# Altium schematic sheet Main.SchDoc
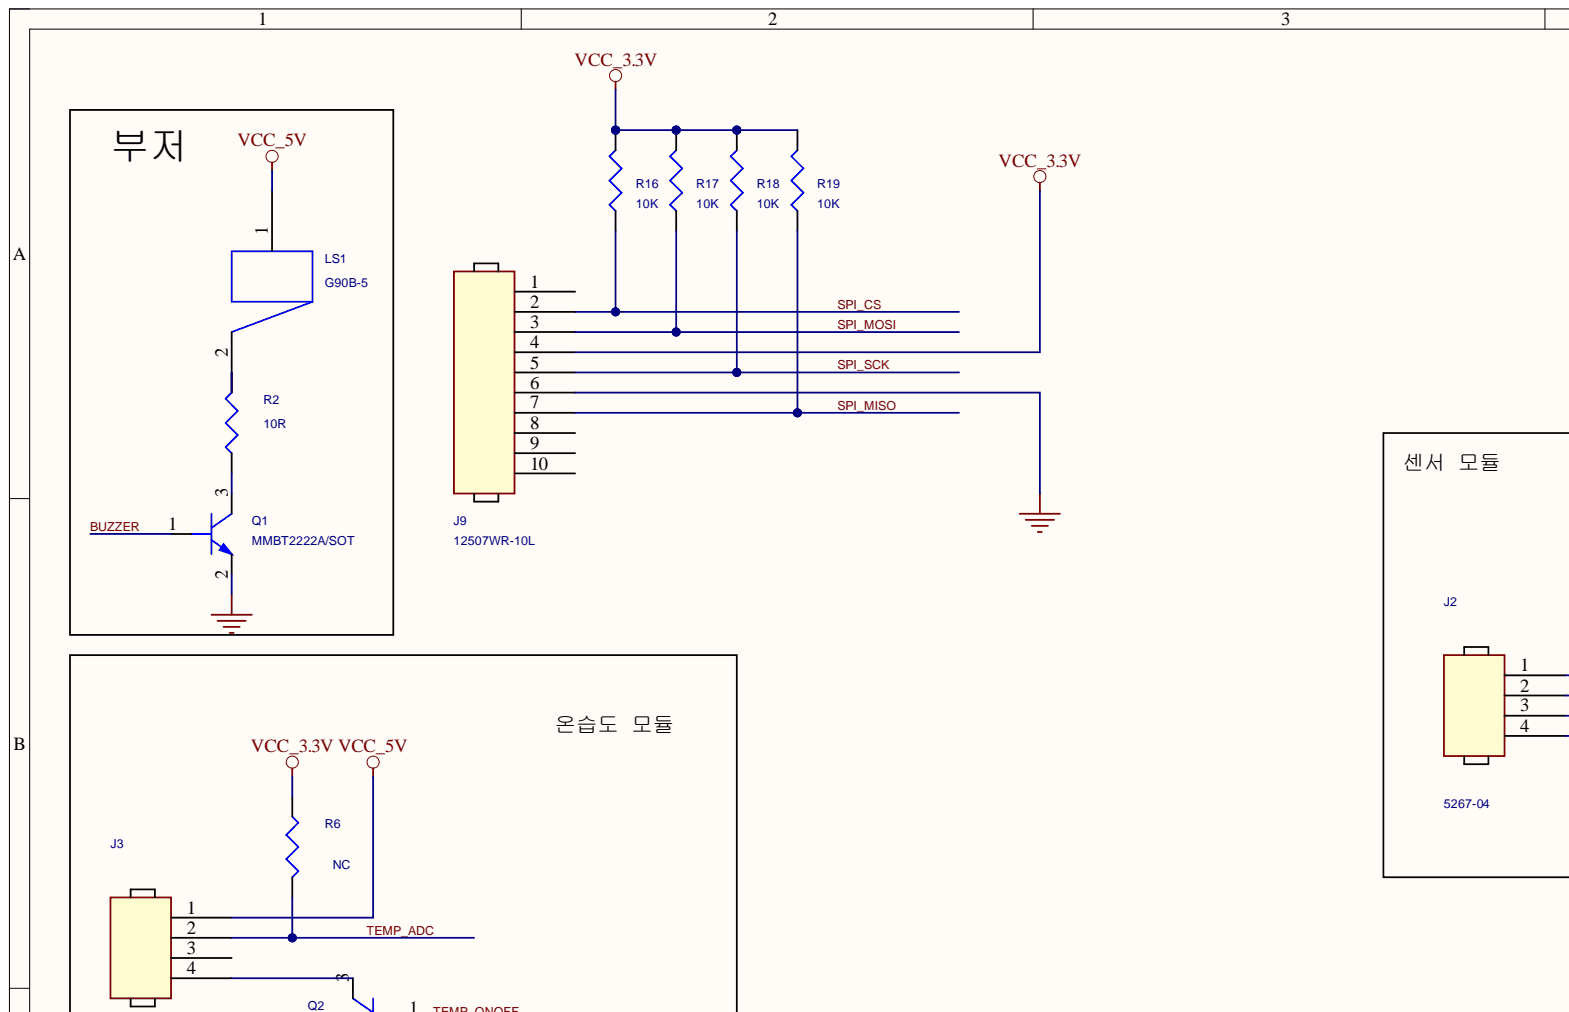
[diagram p0: Main.SchDoc, top left]
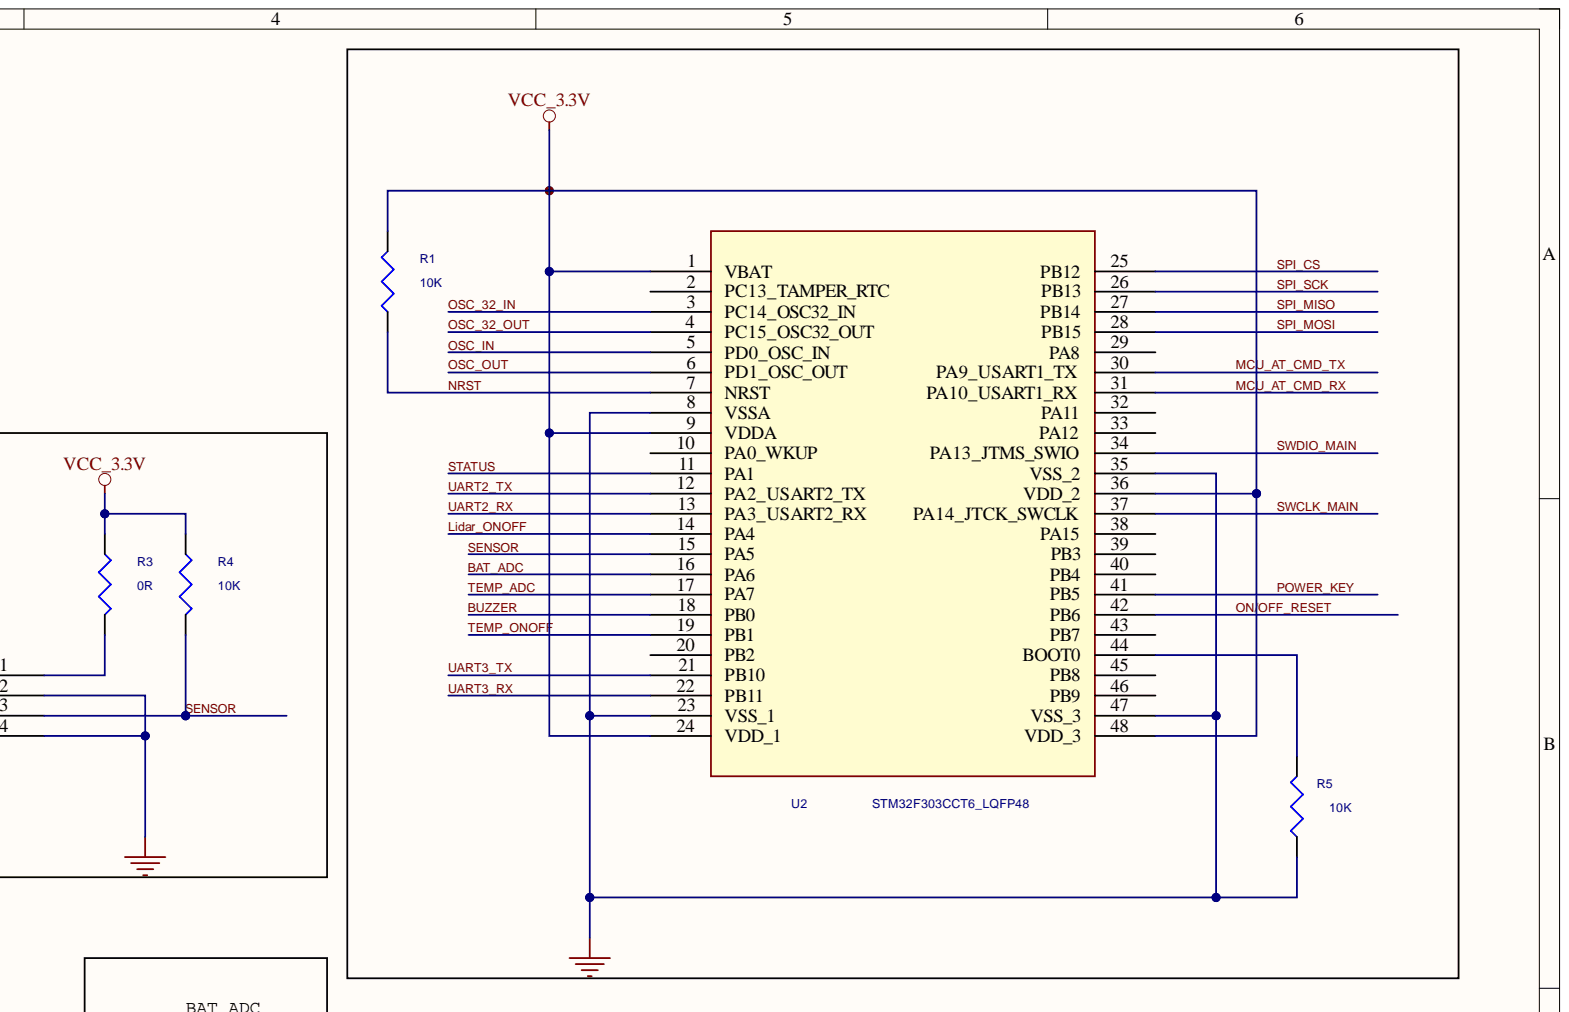
[diagram p1: Main.SchDoc, top right]
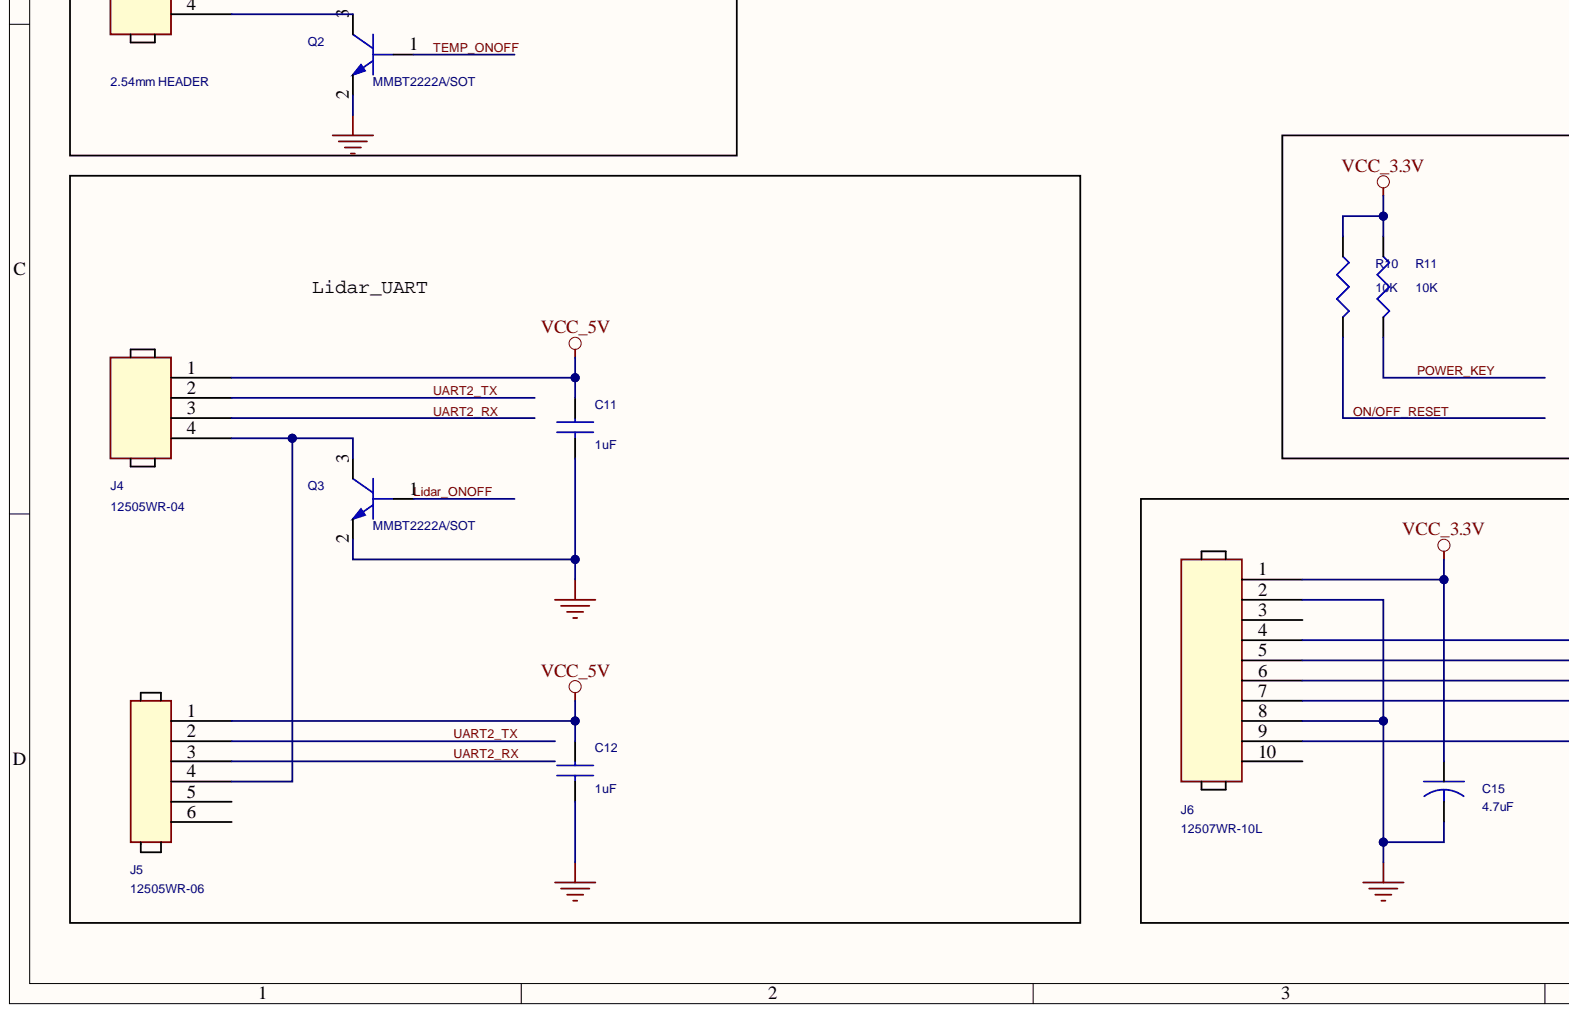
[diagram p2: Main.SchDoc, bottom left]
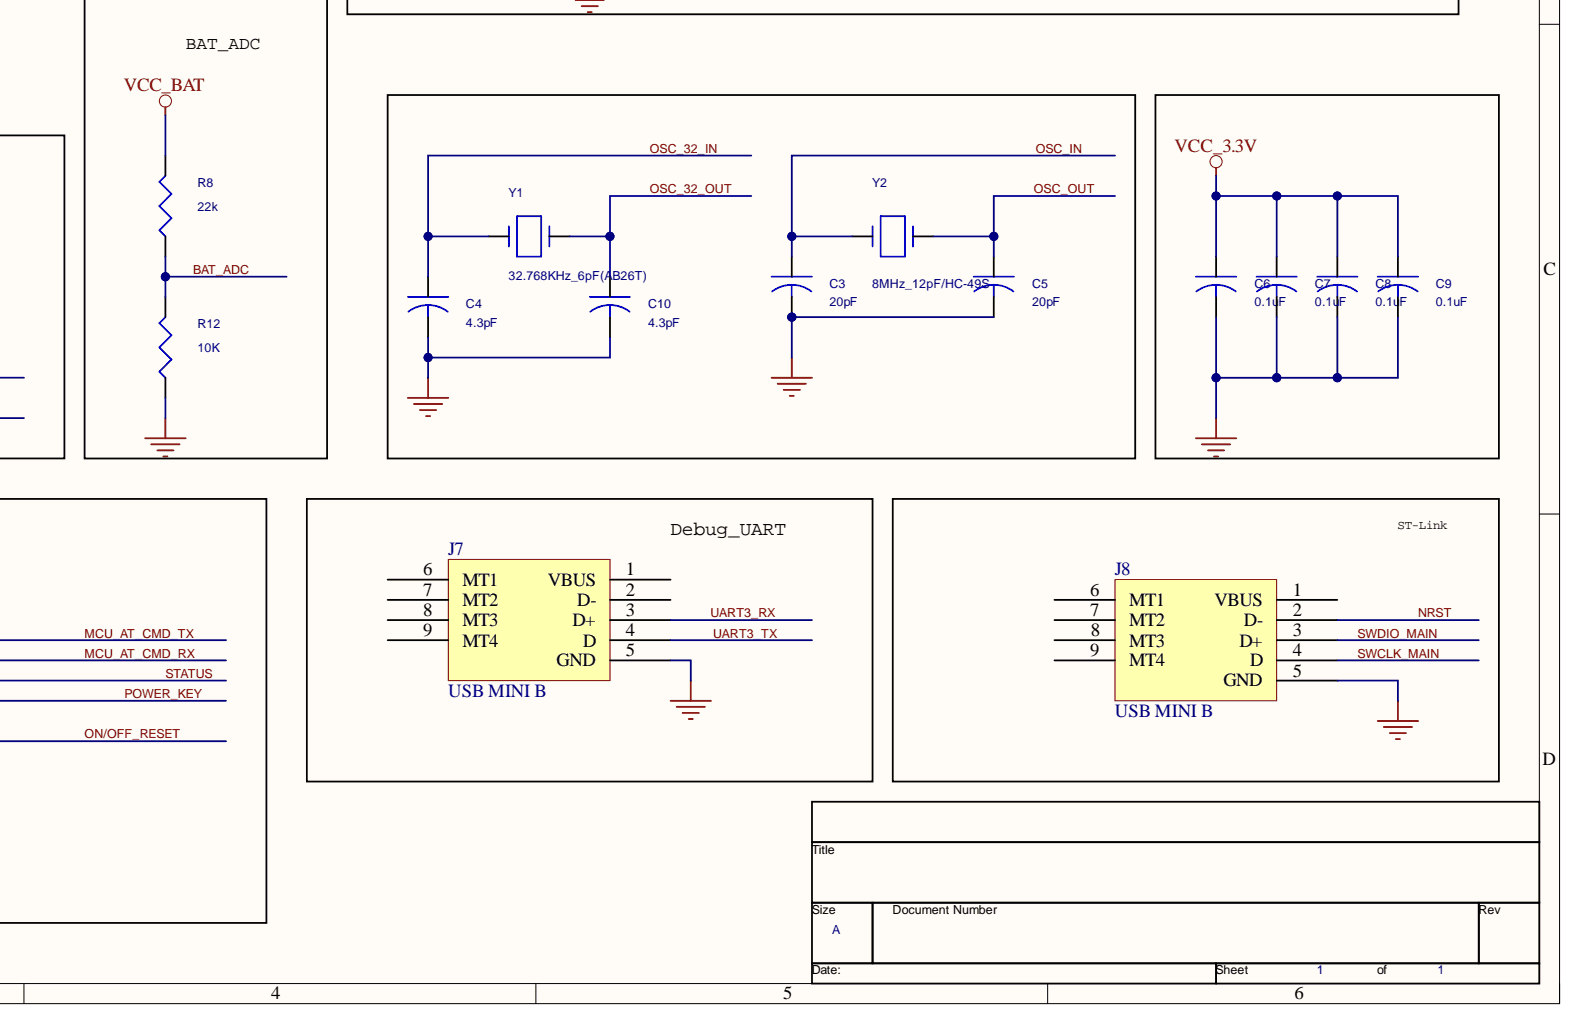
[diagram p3: Main.SchDoc, bottom right]

## Parts
C3  20pF  [DM_CC1608]
C4  4.3pF  [DM_CC1608]
C5  20pF  [DM_CC1608]
C6  0.1uF  [DM_CC1608]
C7  0.1uF  [DM_CC1608]
C8  0.1uF  [DM_CC1608]
C9  0.1uF  [DM_CC1608]
C10  4.3pF  [DM_CC1608]
C11  1uF  [C1608_CRABLED]
C12  1uF  [C1608_CRABLED]
C15  4.7uF  [DM_CC1608]
J2  5267-04  [5267-04]
J3  2.54mm HEADER  [HEADER4_2.54]
J4  12505WR-04  [12505WR-04]
J5  12505WR-06  [12505WR-06]
J6  12507WR-10L  [12507WR-10L]
J7  USB MINI B  [USB-MINI-A]
J8  USB MINI B  [USB-MINI-A]
J9  12507WR-10L  [Micro SD]
LS1  G90B-5  [G90B-5]
Q1  MMBT2222A/SOT  [SOT23]
Q2  MMBT2222A/SOT  [SOT23]
Q3  MMBT2222A/SOT  [SOT23]
R1  10K  [DM_CR1608]
R2  10R  [DM_CR1608]
R3  0R  [DM_CR1608]
R4  10K  [DM_CR1608]
R5  10K  [DM_CR1608]
R6  NC  [DM_CR1608]
R8  22k  [DM_CR1608]
R10  10K  [DM_CR1608]
R11  10K  [DM_CR1608]
R12  10K  [DM_CR1608]
R16  10K  [DM_CR1608]
R17  10K  [DM_CR1608]
R18  10K  [DM_CR1608]
R19  10K  [DM_CR1608]
U2  STM32F303CCT6_LQFP48  [LQFP48P_7X7MM]
Y1  32.768KHz_6pF(AB26T)  [32.768KHZ_6PF(AB26T)]
Y2  8MHz_12pF/HC-49S  [OSC HC-49SMD]

## Nets
GND: C3.2 C4.2 C5.2 C6.2 C7.2 C8.2 C9.2 C10.2 C11.2 C12.2 C15.2 J2.2 J2.4 J6.2 J6.8 J7.5(GND) J8.5(GND) J9.6 Q1.2 Q2.2 Q3.2 R5.1 R12.1 U2.8(VSSA) U2.23(VSS_1) U2.35(VSS_2) U2.47(VSS_3)
VCC_3.3V: C6.1 C7.1 C8.1 C9.1 C15.1 J6.1 J9.4 R1.2 R3.1 R4.1 R6.1 R10.1 R11.1 R16.2 R17.2 R18.2 R19.2 U2.1(VBAT) U2.9(VDDA) U2.24(VDD_1) U2.36(VDD_2) U2.48(VDD_3)
VCC_5V: C11.1 C12.1 J3.1 J4.1 J5.1 LS1.1
VCC_BAT: R8.2
BAT_ADC: R8.1 R12.2 U2.16(PA6)
BUZZER: Q1.1 U2.18(PB0)
Lidar_ONOFF: Q3.1 U2.14(PA4)
MCU_AT_CMD_RX: J6.5 U2.31(PA10_USART1_RX)
MCU_AT_CMD_TX: J6.4 U2.30(PA9_USART1_TX)
NRST: J8.2(D-) R1.1 U2.7(NRST)
ON/OFF_RESET: J6.9 R10.2 U2.42(PB6)
OSC_32_IN: C4.1 U2.3(PC14_OSC32_IN) Y1.2
OSC_32_OUT: C10.1 U2.4(PC15_OSC32_OUT) Y1.1
OSC_IN: C3.1 U2.5(PD0_OSC_IN) Y2.1
OSC_OUT: C5.1 U2.6(PD1_OSC_OUT) Y2.2
POWER_KEY: J6.7 R11.2 U2.41(PB5)
SENSOR: J2.3 R4.2 U2.15(PA5)
SPI_CS: J9.2 R16.1 U2.25(PB12)
SPI_MISO: J9.7 R19.1 U2.27(PB14)
SPI_MOSI: J9.3 R17.1 U2.28(PB15)
SPI_SCK: J9.5 R18.1 U2.26(PB13)
STATUS: J6.6 U2.11(PA1)
SWCLK_MAIN: J8.4(D) U2.37(PA14_JTCK_SWCLK)
SWDIO_MAIN: J8.3(D+) U2.34(PA13_JTMS_SWIO)
TEMP_ADC: J3.2 R6.2 U2.17(PA7)
TEMP_ONOFF: Q2.1 U2.19(PB1)
UART2_RX: J4.3 J5.3 U2.13(PA3_USART2_RX)
UART2_TX: J4.2 J5.2 U2.12(PA2_USART2_TX)
UART3_RX: J7.3(D+) U2.22(PB11)
UART3_TX: J7.4(D) U2.21(PB10)
NetJ2_1: J2.1 R3.2
NetJ3_4: J3.4 Q2.3
NetJ4_4: J4.4 J5.4 Q3.3
NetLS1_2: LS1.2 R2.2
NetQ1_3: Q1.3 R2.1
NetR5_2: R5.2 U2.44(BOOT0)

Not connected: J3 3; J5 5 6; J6 3 10; J7 1(VBUS) 2(D-) 6(MT1) 7(MT2) 8(MT3) 9(MT4); J8 1(VBUS) 6(MT1) 7(MT2) 8(MT3) 9(MT4); J9 1 8 9 10; U2 2(PC13_TAMPER_RTC) 10(PA0_WKUP) 20(PB2) 29(PA8) 32(PA11) 33(PA12) 38(PA15) 39(PB3) 40(PB4) 43(PB7) 45(PB8) 46(PB9)

## Library data of the parts
C3-C10 C15 = CAP: Component Kind: Standard
C11 C12 = CAP NP: Component Kind: Standard
J2-J4 = HEADER 4: Component Kind: Standard
J5 = HEADER 6: Component Kind: Standard
J6 J9 = HEADER 10: Component Kind: Standard
LS1 = BUZZER: Component Kind: Standard
Q1-Q3 = MMBT2222A/SOT_1: Component Kind: Standard
R1-R6 R8 R10-R12 R16-R19 = R: Component Kind: Standard
U2 = STM32F103C8: Component Kind: Standard
Y1 Y2 = CRYSTAL: Component Kind: Standard
J7 J8 = USB MINI B: Component Kind: Standard; Library Name: JRLee.SchLib
